# Altium schematic sheet RF.SchDoc(RF)
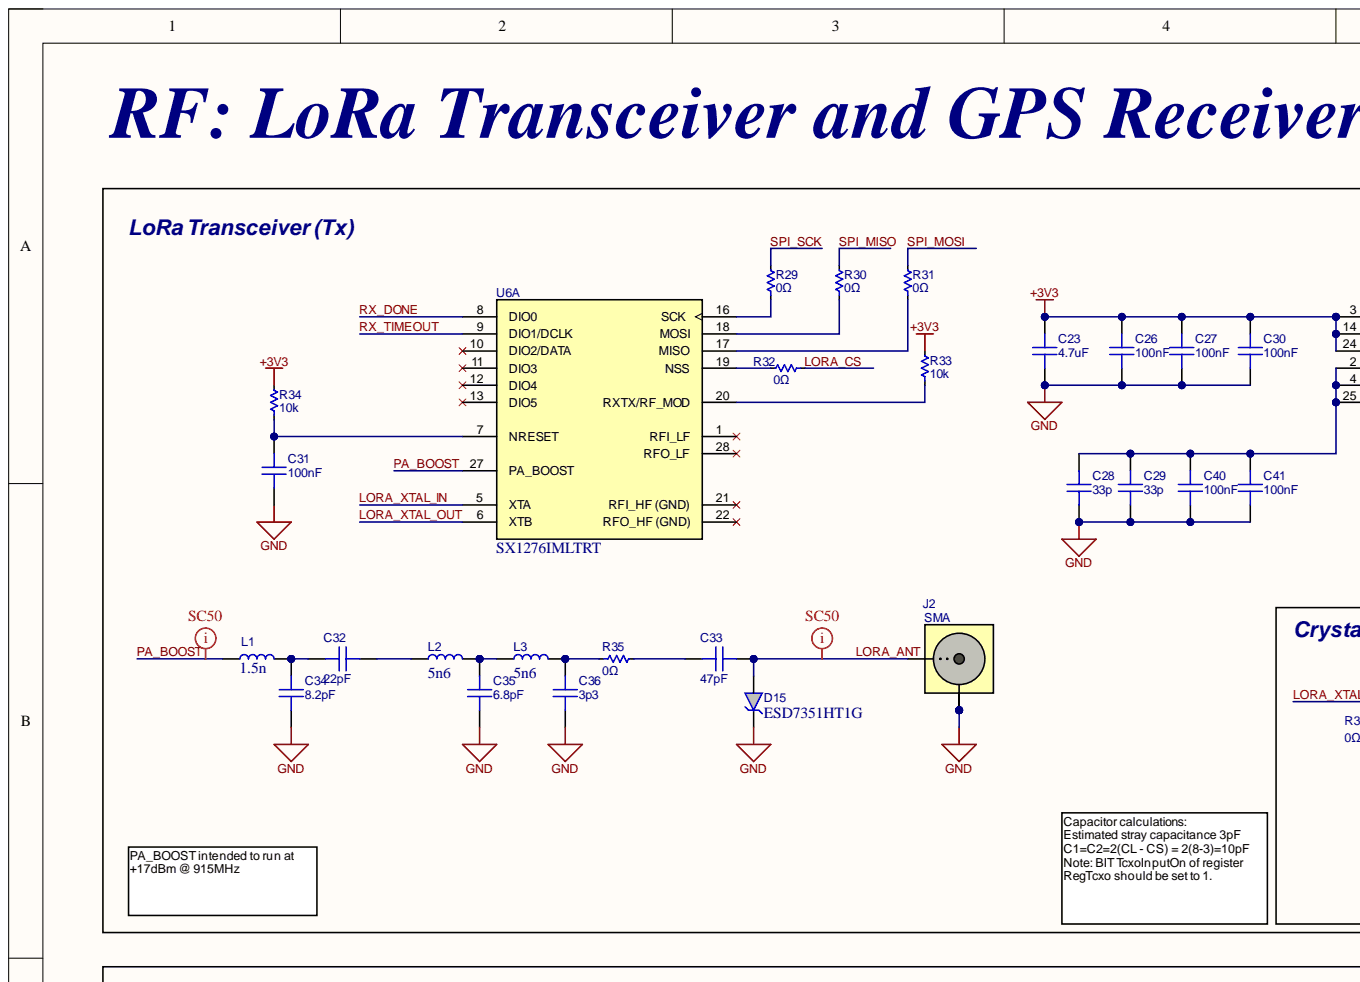
[diagram p0: RF.SchDoc, top left]
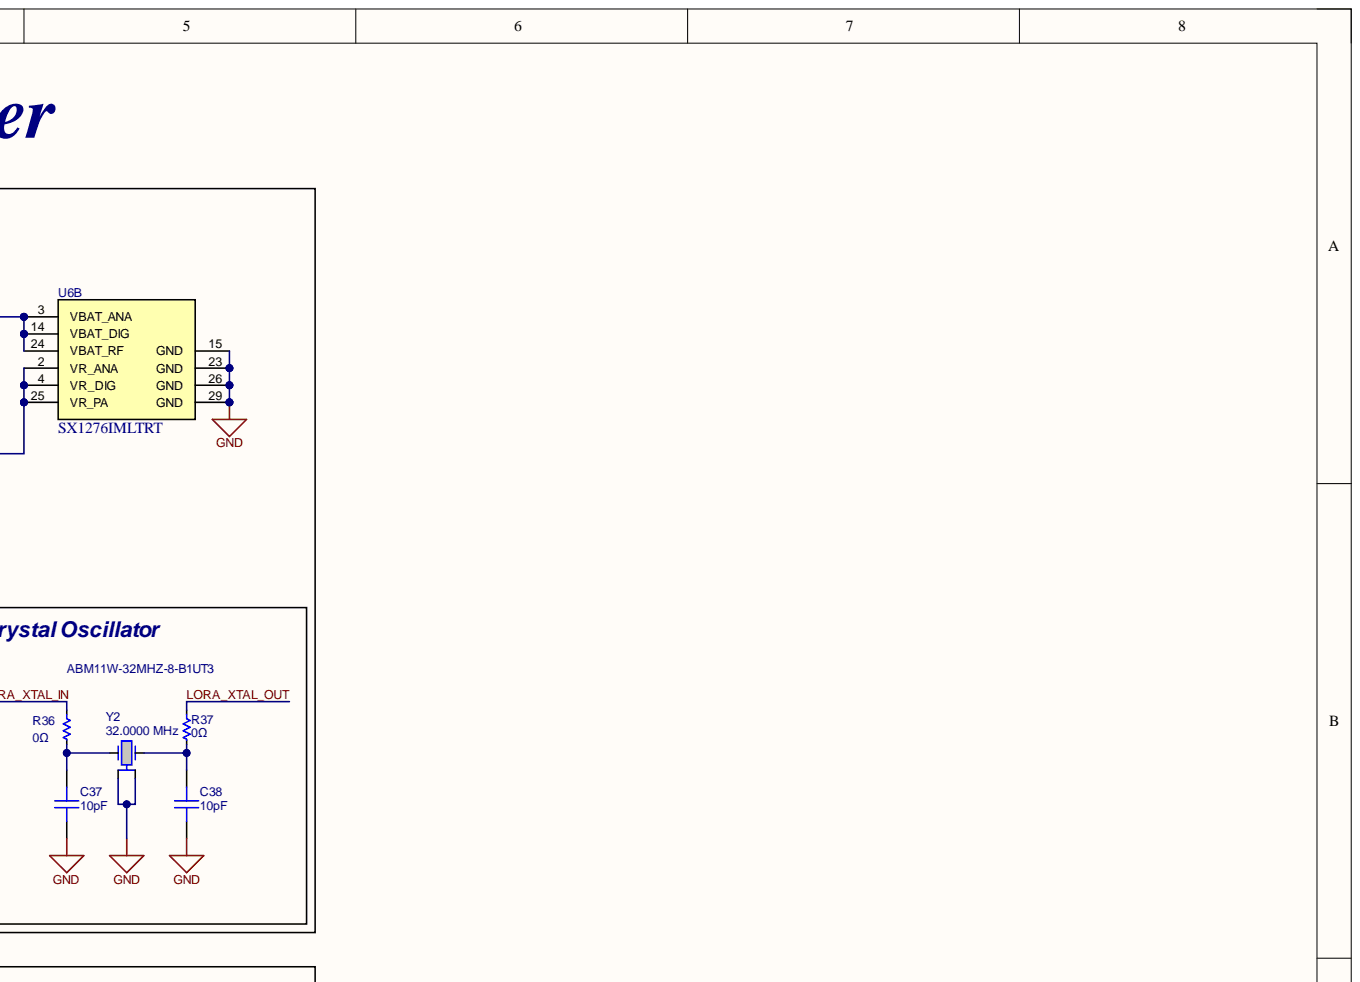
[diagram p1: RF.SchDoc, top right]
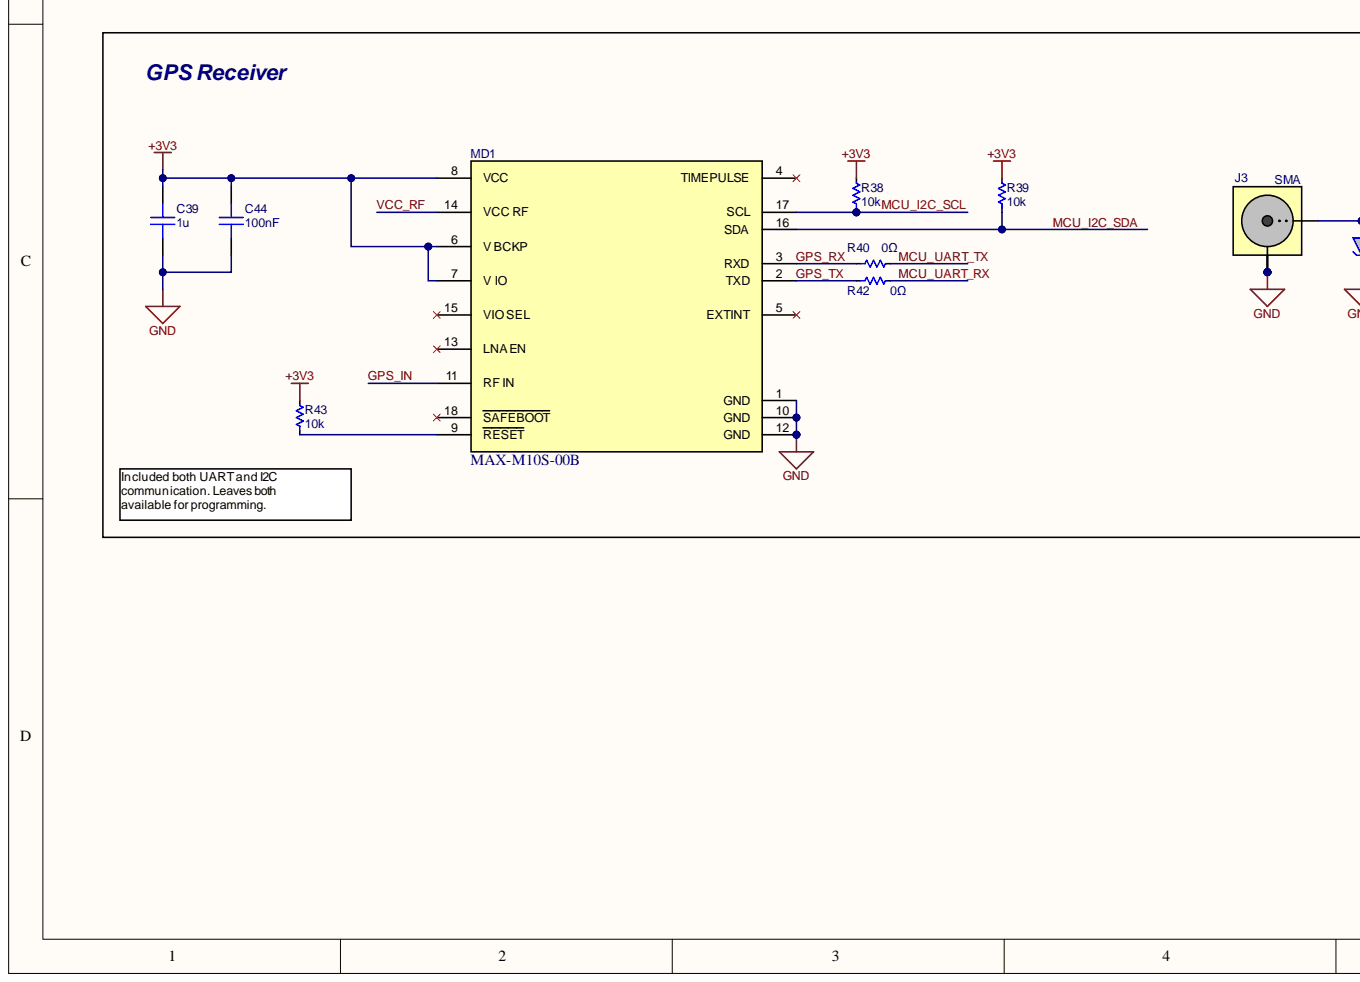
[diagram p2: RF.SchDoc, bottom left]
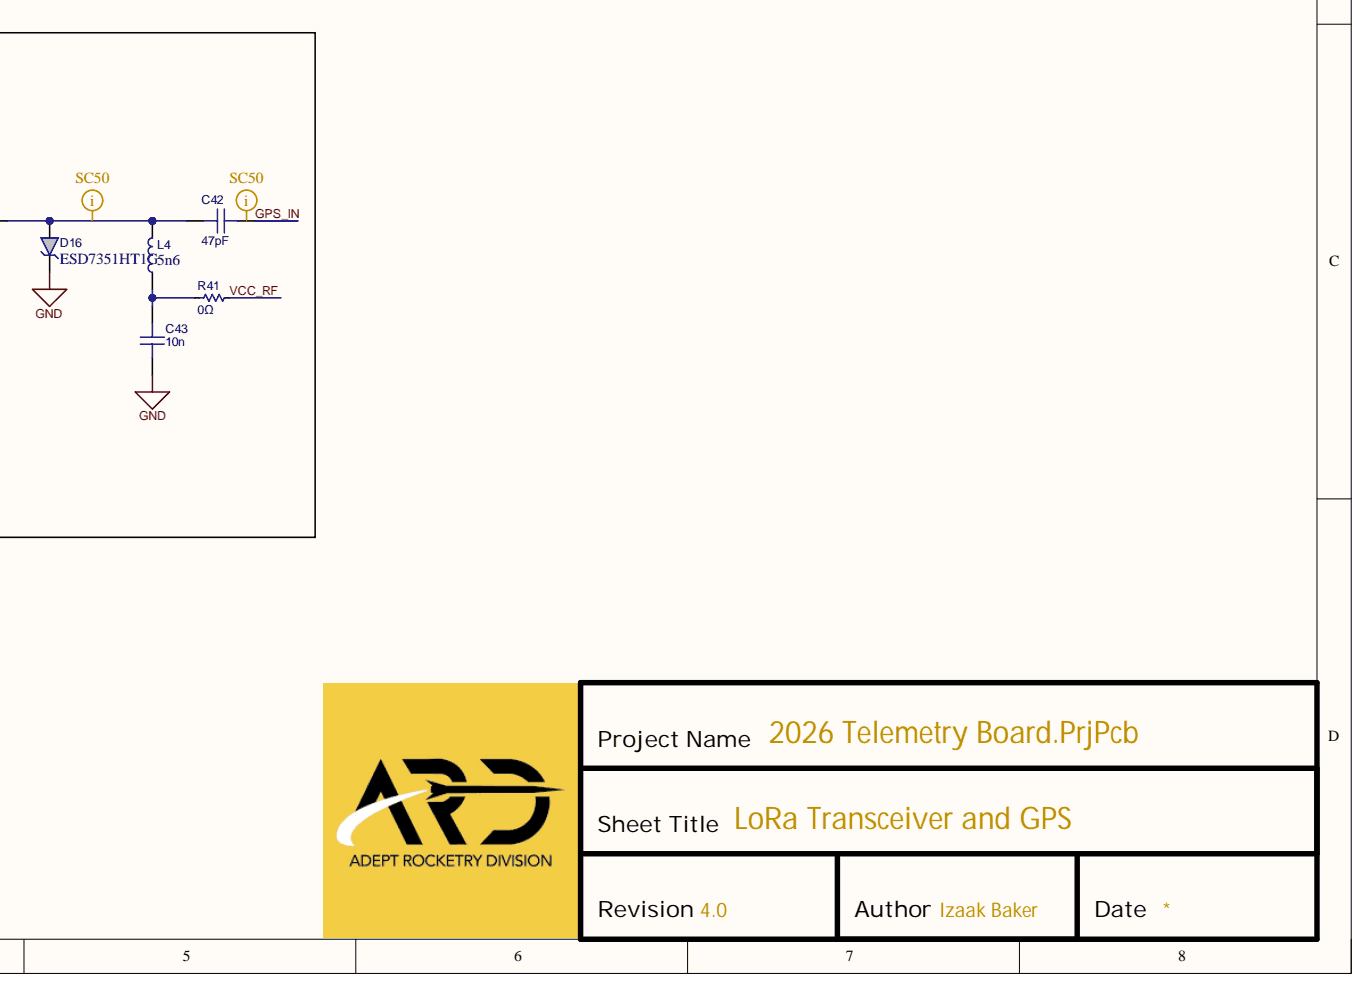
[diagram p3: RF.SchDoc, bottom right]

## Parts
C23  value 4.7uF  [CAP0603]  (hidden comment: CGA0603X7R475K160JT)
C26  value 100nF  [CAP0402]  (hidden comment: C0402C104K4RACTU)
C27  value 100nF  [CAP0402]  (hidden comment: C0402C104K4RACTU)
C28  value 33p  [CAP0402]  (hidden comment: 0402CG330J500NT)
C29  value 33p  [CAP0402]  (hidden comment: 0402CG330J500NT)
C30  value 100nF  [CAP0402]  (hidden comment: C0402C104K4RACTU)
C31  value 100nF  [CAP0402]  (hidden comment: C0402C104K4RACTU)
C32  value 22pF  [CAP0603]  (hidden comment: CGA0603C0G220J101JT)
C33  value 47pF  [CAP0603]  (hidden comment: 0603CG470J201NT)
C34  value 8.2pF  [CAP0603]  (hidden comment: 0603CG8R2C500NT)
C35  value 6.8pF  [CAP0603]  (hidden comment: 0603CG6R8C500NT)
C36  value 3p3  [CAP0402]  (hidden comment: GRM1555C1H3R3CA01D)
C37  value 10pF  [CAP0603]  (hidden comment: 0603CG100J101NT)
C38  value 10pF  [CAP0603]  (hidden comment: 0603CG100J101NT)
C39  value 1u  [CAP0603]  (hidden comment: CL10B105KP8NNNC)
C40  value 100nF  [CAP0402]  (hidden comment: C0402C104K4RACTU)
C41  value 100nF  [CAP0402]  (hidden comment: C0402C104K4RACTU)
C42  value 47pF  [CAP0603]  (hidden comment: 0603CG470J201NT)
C43  value 10n  [CAP0402]  (hidden comment: CL05B103KB5NNNC)
C44  value 100nF  [CAP0402]  (hidden comment: C0402C104K4RACTU)
D15  ESD7351HT1G  [FP-477-02-IPC_B]
D16  ESD7351HT1G  [FP-477-02-IPC_B]
J2  CONREVSMA002-G  [SMA R/A THT]  (value not drawn)
J3  CONREVSMA002-G  [SMA R/A THT]  (value not drawn)
L1  value 1.5n  [IND0402]  (hidden comment: LQW15AN1N5B00D)
L2  value 5n6  [IND0402]  (hidden comment: LQW15AN5N6C10D)
L3  value 5n6  [IND0402]  (hidden comment: LQW15AN5N6C10D)
L4  value 5n6  [IND0402]  (hidden comment: LQW15AN5N6C10D)
MD1  MAX-M10S-00B  [FP-MAX-M10S-00B-MFG]
R29  value 0Ω  [RES0603]  (hidden comment: 0603WAF0000T5E)
R30  value 0Ω  [RES0603]  (hidden comment: 0603WAF0000T5E)
R31  value 0Ω  [RES0603]  (hidden comment: 0603WAF0000T5E)
R32  value 0Ω  [RES0603]  (hidden comment: 0603WAF0000T5E)
R33  value 10k  [RES0603]  (hidden comment: 0603WAF1002T5E)
R34  value 10k  [RES0603]  (hidden comment: 0603WAF1002T5E)
R35  value 0Ω  [RES0603]  (hidden comment: 0603WAF0000T5E)
R36  value 0Ω  [RES0603]  (hidden comment: 0603WAF0000T5E)
R37  value 0Ω  [RES0603]  (hidden comment: 0603WAF0000T5E)
R38  value 10k  [RES0603]  (hidden comment: 0603WAF1002T5E)
R39  value 10k  [RES0603]  (hidden comment: 0603WAF1002T5E)
R40  value 0Ω  [RES0603]  (hidden comment: 0603WAF0000T5E)
R41  value 0Ω  [RES0603]  (hidden comment: 0603WAF0000T5E)
R42  value 0Ω  [RES0603]  (hidden comment: 0603WAF0000T5E)
R43  value 10k  [RES0603]  (hidden comment: 0603WAF1002T5E)
U6  SX1276IMLTRT  [SMTC-QFN-28_V]  (parts A,B)
Y2  ABM11W-32MHZ-8-B1UT3  [ABM11W-32MHZ-8-B1UT3-Footprint-1]

## Nets
+3V3: C23.2 C26.2 C27.2 C30.2 C39.1 C44.2 MD1.6(V_BCKP) MD1.7(V_IO) MD1.8(VCC) R33.2 R34.2 R38.2 R39.2 R43.2 U6.3(VBAT_ANA) U6.14(VBAT_DIG) U6.24(VBAT_RF)
GND: C23.1 C26.1 C27.1 C28.2 C29.2 C30.1 C31.1 C34.1 C35.1 C36.1 C37.1 C38.1 C39.2 C40.1 C41.1 C43.1 C44.1 D15.2 D16.2 J2.2 J2.3 J2.4 J2.5 J3.2 J3.3 J3.4 J3.5 MD1.1(GND) MD1.10(GND) MD1.12(GND) U6.15(GND) U6.23(GND) U6.26(GND) U6.29(GND) Y2.2 Y2.4
GPS_IN: C42.2 MD1.11(RF_IN)
GPS_RX: MD1.3(RXD) R40.1
GPS_TX: MD1.2(TXD) R42.1
LORA_ANT: C33.2 D15.1 J2.1
LORA_CS: R32.1
LORA_XTAL_IN: R36.1 U6.5(XTA)
LORA_XTAL_OUT: R37.1 U6.6(XTB)
MCU_I2C_SCL: MD1.17(SCL) R38.1
MCU_I2C_SDA: MD1.16(SDA) R39.1
MCU_UART_RX: R42.2
MCU_UART_TX: R40.2
PA_BOOST: L1.1 U6.27(PA_BOOST)
RX_DONE: U6.8(DIO0)
RX_TIMEOUT: U6.9(DIO1/DCLK)
SPI_MISO: R30.2
SPI_MOSI: R31.2
SPI_SCK: R29.2
VCC_RF: MD1.14(VCC_RF) R41.2
NetC28_1: C28.1 C29.1 C40.2 C41.2 U6.2(VR_ANA) U6.4(VR_DIG) U6.25(VR_PA)
NetC31_2: C31.2 R34.1 U6.7(NRESET)
NetC32_1: C32.1 C34.2 L1.2
NetC32_2: C32.2 L2.1
NetC33_1: C33.1 R35.2
NetC35_2: C35.2 L2.2 L3.1
NetC36_2: C36.2 L3.2 R35.1
NetC37_2: C37.2 R36.2 Y2.1
NetC38_2: C38.2 R37.2 Y2.3
NetC42_1: C42.1 D16.1 J3.1 L4.2
NetC43_2: C43.2 L4.1 R41.1
NetMD1_9: MD1.9(RESET) R43.1
NetR29_1: R29.1 U6.16(SCK)
NetR30_1: R30.1 U6.18(MOSI)
NetR31_1: R31.1 U6.17(MISO)
NetR32_2: R32.2 U6.19(NSS)
NetR33_1: R33.1 U6.20(RXTX/RF_MOD)

Not connected: MD1 4(TIMEPULSE) 5(EXTINT) 13(LNA_EN) 15(VIO_SEL) 18(SAFEBOOT); U6 1(RFI_LF) 10(DIO2/DATA) 11(DIO3) 12(DIO4) 13(DIO5) 21(RFI_HF_(GND)) 22(RFO_HF_(GND)) 28(RFO_LF)

## Library data of the parts
C23 = CMP-00136-3: 16V 4.7uF X7R ±10% 0603 Multilayer Ceramic Capacitors MLCC - SMD/SMT ROHS; Manufacturer: HRE; Component Kind: Standard; LCSC Part #: C22399629; Manufacturer PN: CGA0603X7R475K160JT; Package: 0603; Supplier: JLPCB; Supplier PN: C22399629; Voltage - Rated: 16V; Library Name: Adept Rocketry Division (ARD)
C26 C27 C30 C31 C40 C41 C44 = CMP-001-00085-4: Ceramic Capacitors MLCC - SMD/SMT 16V 0.1uF X7R 0402 10%; Case/Package: 0402; Component Kind: Standard; Max Operating Temperature: 125°C; Min Operating Temperature: -55°C; Mounting Technology: Surface Mount; Pins: 2; Tolerance: 10%; Voltage Rating: 16V; Library Name: Adept Rocketry Division (ARD)
C28 C29 = CMP-00078-2: 33pF 50V C0G ±5% 0402 Multilayer Ceramic Capacitors MLCC; Manufacturer: FH; Component Kind: Standard; LCSC Part #: C1562; Manufacturer PN: 0402CG330J500NT; Max Operating Temperature: 125°C; Min Operating Temperature: -55°C; Package: 0402; Supplier: LCSC; Supplier PN: C1562; Temperature Coefficient: C0G; Tolerance: 5%; Voltage - Rated: 50V; Library Name: Adept Rocketry Division (ARD)
C32 = CMP-00023-3: 100V 22pF C0G ±5% 0603 Multilayer Ceramic Capacitors MLCC - SMD/SMT ROHS; Manufacturer: HRE; Component Kind: Standard; LCSC Part #: C22374866; Manufacturer PN: CGA0603C0G220J101JT; Package: 0603; Supplier: JLPCB; Supplier PN: C22374866; Voltage - Rated: 100V; Library Name: Adept Rocketry Division (ARD)
C33 C42 = CMP-001-00100-2: 200V 47pF C0G 0603 Multilayer Ceramic Capacitors MLCC - SMD/SMT ROHS; Component Kind: Standard; JLCPCB Part #: C60302; MFR Part #: 0603CG470J201NT; Library Name: Adept Rocketry Division (ARD)
C34 = CMP-001-00090-2: 50V 8.2pF C0G 0603 Multilayer Ceramic Capacitors MLCC - SMD/SMT ROHS; Component Kind: Standard; JLCPCB Part #: C1685; MFR Part #: 0603CG8R2C500NT; Library Name: Adept Rocketry Division (ARD)
C35 = CMP-001-00098-3: 50V 6.8pF C0G 0603 Multilayer Ceramic Capacitors MLCC - SMD/SMT ROHS; Component Kind: Standard; JLCPCB Part #: C1679; MFR Part #: 0603CG6R8C500NT; Library Name: Adept Rocketry Division (ARD)
C36 = CMP-00107-2: CAP CER 3.3PF 50V C0G/NP0 0402; Manufacturer: Murata; Temperature Coefficient: C0G; Tolerance: 5%; Component Kind: Standard; LCSC Part #: C76968; Manufacturer PN: GRM1555C1H3R3CA01D; Max Operating Temperature: 125°C; Min Operating Temperature: -55°C; Package: 0402; Supplier: Digikey; Supplier PN: 490-5938-2-ND; Voltage - Rated: 50V; Library Name: Adept Rocketry Division (ARD)
C37 C38 = CMP-001-00102-2: 50V 10pF C0G 0603 Multilayer Ceramic Capacitors MLCC - SMD/SMT ROHS; Component Kind: Standard; JLCPCB Part #: C56033; MFR Part #: 0603CG100J101NT; Library Name: Adept Rocketry Division (ARD)
C39 = CMP-00075-3: CAP CER 1UF 10V X7R 0603; Manufacturer: Samsung Electro-Mechanics; Tolerance: 10%; Component Kind: Standard; LCSC Part #: C95843; Manufacturer PN: CL10B105KP8NNNC; Max Operating Temperature: -55°C; Min Operating Temperature: 125°C; Package: 0603; Supplier: Digikey; Supplier PN: 1276-1946-2-ND; Temperature Coefficient: X7R; Voltage - Rated: 10V; Library Name: Adept Rocketry Division (ARD)
C43 = CMP-00110-2: CAP CER 10000PF 50V X7R 0402; Manufacturer: Samsung Electro-Mechanics; Tolerance: 10%; Component Kind: Standard; LCSC Part #: C15195; Manufacturer PN: CL05B103KB5NNNC; Max Operating Temperature: 125°C; Min Operating Temperature: -55°C; Package: 0402; Supplier: Digikey; Supplier PN: 1276-1028-2-ND; Temperature Coefficient: X7R; Voltage - Rated: 50V; Library Name: Adept Rocketry Division (ARD)
D15 D16 = CMP-00160-1: Tvs Diodes & Esd Suppressors; ESD7351HT1G; on Semiconductor; 3.3 V; 10 V; 5 V; 5 V; Manufacturer Lifecycle Status: ACTIVE; Breakdown Voltage: 5V; Clamping Voltage: 10V; Direction: Unidirectional; Max Junction Temperature (Tj): 150°C; Max Power Dissipation: 150mW; Number of Channels: 1; Peak Pulse Current: 3A; Peak Reverse Current: 50nA; Power Line Protection: No; Reverse Standoff Voltage: 3.3V; Value: 430fF; Case/Package: SOD; China RoHS: Compliant; Component Kind: Standard; Composition: Zener; Height: 1mm; Lead Free: Lead Free; Lifecycle Status: Production; Manufacturer PN: ESD7351HT1G; Max Operating Temperature: 150°C; Min Breakdown Voltage: 5V; Min Operating Temperature: -55°C; Mounting Technology: Surface Mount; Number of Elements: 1; Number of Terminals: 2; Number of Unidirectional Channels: 1; Peak Pulse Power: 150mW; Polarity: Unidirectional; REACH SVHC: Yes; RoHS: Compliant; Schedule B: 8536300000, 8536300000; Supplier PN: C233436; Library Name: Adept Rocketry Division (ARD)
J2 J3 = CMP-00106-2: CONN RP-SMA RCPT R/A 50 OHM PCB; Manufacturer: TE Connectivity Linx; Body Material: Gold; Centre Contact Material: Beryllium Copper; Connector Type: Receptace, Male Pin; Dielectric Material: Polytetrafluoroethylene (PTFE); Frequency (Max): 12.4 GHz; Impedance: 50 Ohm; Component Kind: Standard; LCSC Part #: C3174982; Manufacturer PN: CONREVSMA002-G; Max. Operating Temperature: 165°C; Min. Operating Temperature: -65°C; Supplier: Digikey; Supplier PN: CONREVSMA002-G-ND; Library Name: Adept Rocketry Division (ARD)
L1 = CMP-00156-1: FIXED IND 1.5NH 460MA 0.16OHM SMD; Manufacturer: Murata; DC Resistance: 160m; Frequency - Self Resonant: 5GHz; Tolerance: 5%; Component Kind: Standard; Current Rating: 460mA; LCSC Part #: C18221; Manufacturer PN: LQW15AN1N5B00D; Max Operating Temperature: 125°C; Min Operating Temperature: -55°C; Package: 0402; Supplier: Digikey; Supplier PN: 490-1148-2-ND; Library Name: Adept Rocketry Division (ARD)
L2-L4 = CMP-00157-1: FIXED IND 5.6NH 200MA 1.17OHM SMD; Manufacturer: Murata; DC Resistance: 800m; Frequency - Self Resonant: 2.8GHz; Tolerance: 5%; Component Kind: Standard; Current Rating: 200mA; LCSC Part #: C91626; Manufacturer PN: LQW15AN5N6C10D; Max Operating Temperature: 125°C; Min Operating Temperature: -55°C; Package: 0402; Supplier: Digikey; Supplier PN: 490-1155-2-ND; Library Name: Adept Rocketry Division (ARD)
MD1 = CMP-00025-00004-1: Gnss / Gps Modules U-Blox M10 Gnss Module Rom, Tcxo, Lna, Saw Lcc, 9.7X10 Mm, 500 Pcs/Reel; Component Kind: Standard; Max Operating Temperature: 85°C; Min Operating Temperature: -40°C; Supplier PN: C4153167; Library Name: Adept Rocketry Division (ARD)
R29-R32 R35-R37 R40-R42 = CMP-RES-00000-2: JUMPER 100mW Thick Film Resistors 75V ±100ppm/℃ ±1% 10kΩ 0603 Chip Resistor - Surface Mount ROHS; Manufacturer: UNI-ROYAL; Component Kind: Standard; LCSC Part #: C25804; Manufacturer PN: 0603WAF0000T5E; Max Operating Temperature: 155°C; Min Operating Temperature: -55°C; Package: 0603; Power: 100mW; Supplier: LCSC; Supplier PN: C21189; Tolerance: 1%; Library Name: Adept Rocketry Division (ARD)
R33 R34 R38 R39 R43 = CMP-00069-4: 100mW Thick Film Resistors 75V ±100ppm/℃ ±1% 10kΩ 0603 Chip Resistor - Surface Mount ROHS; Manufacturer: UNI-ROYAL; Component Kind: Standard; LCSC Part #: C25804; Manufacturer PN: 0603WAF1002T5E; Max Operating Temperature: 155°C; Min Operating Temperature: -55°C; Package: 0603; Power: 100mW; Supplier: LCSC; Supplier PN: C25804; Tolerance: 1%; Library Name: Adept Rocketry Division (ARD)
U6 = CMP-00025-00003-2: RF Transceiver FSK/GFSK/GMSK/MSK/OOK 1.8V to 3.7V 137MHz to 1020MHz 28-Pin QFN T/R; Case/Package: VQFN; Component Kind: Standard; Frequency: 137MHz; Manufacturer PN: SX1276IMLTRT; Max Operating Temperature: 85°C; Min Operating Temperature: -40°C; Supplier PN: C80171; Library Name: Adept Rocketry Division (ARD)
Y2 = CMP-003-00034-1: 32.000 MHz Crystal Unit; Component Kind: Standard; Library Name: Adept Rocketry Division (ARD)
